# Altium schematic sheet 08_BB_Processor.SchDoc(08_BB_Processor)
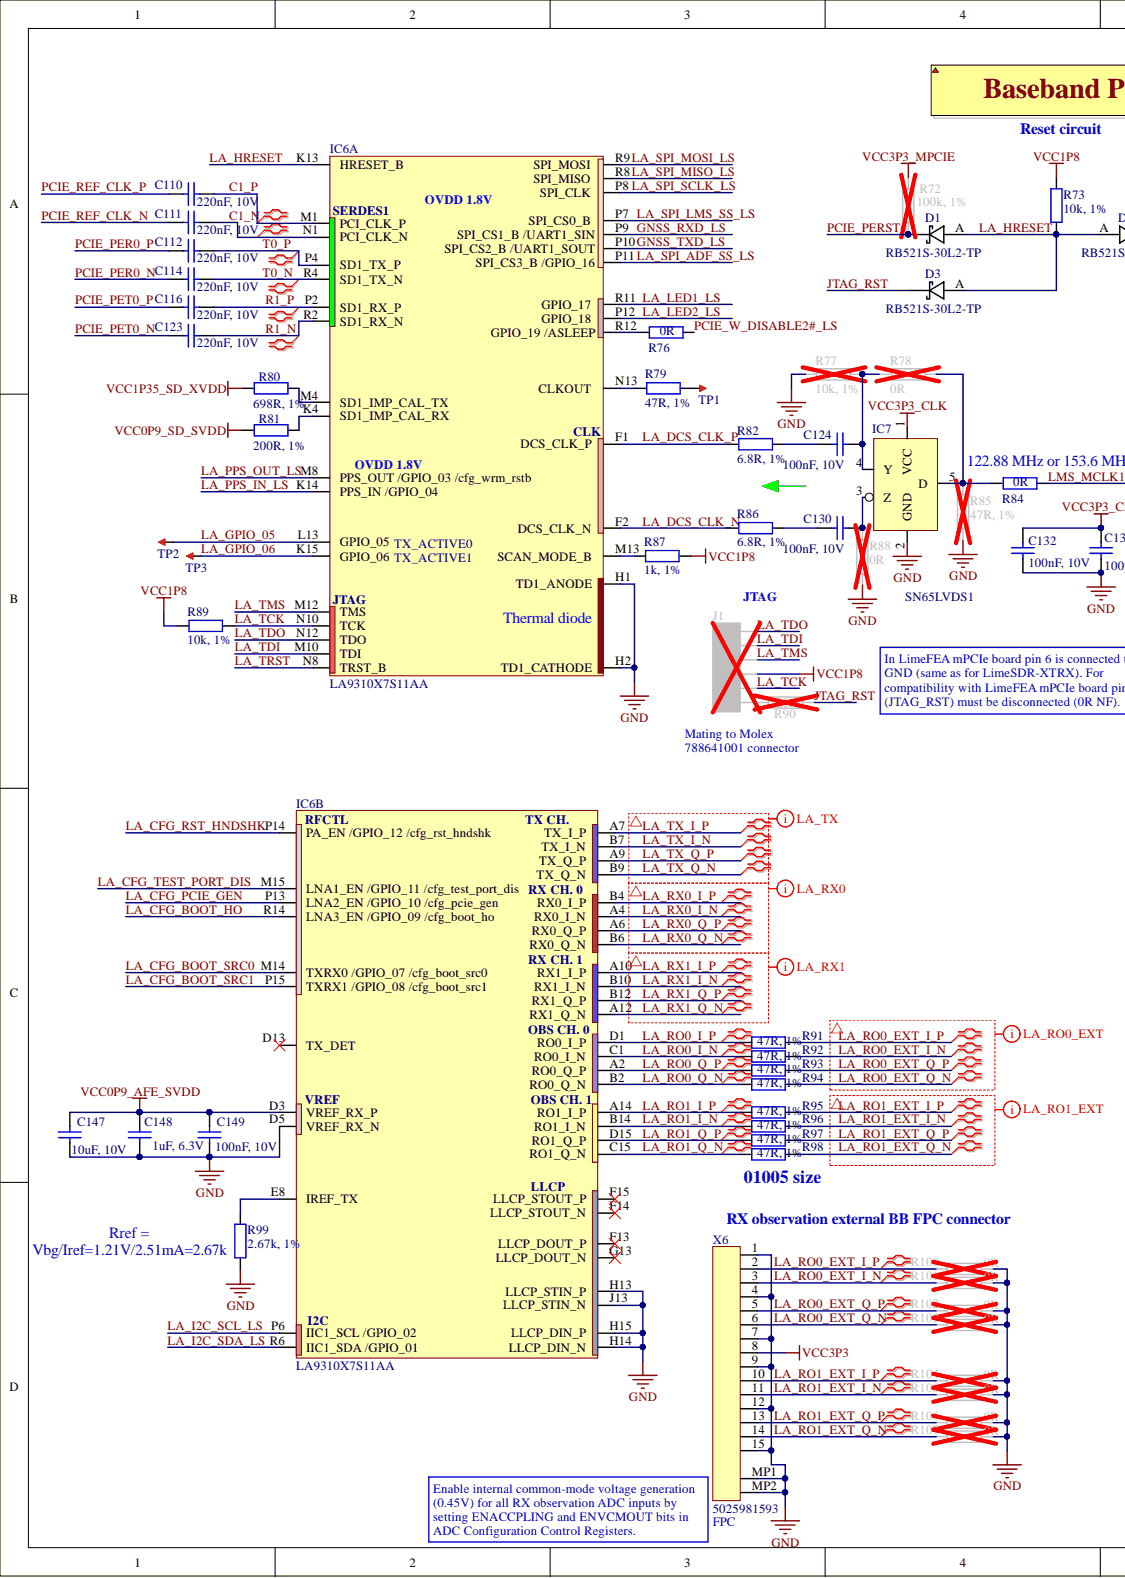
[diagram p0: 08_BB_Processor.SchDoc, left]
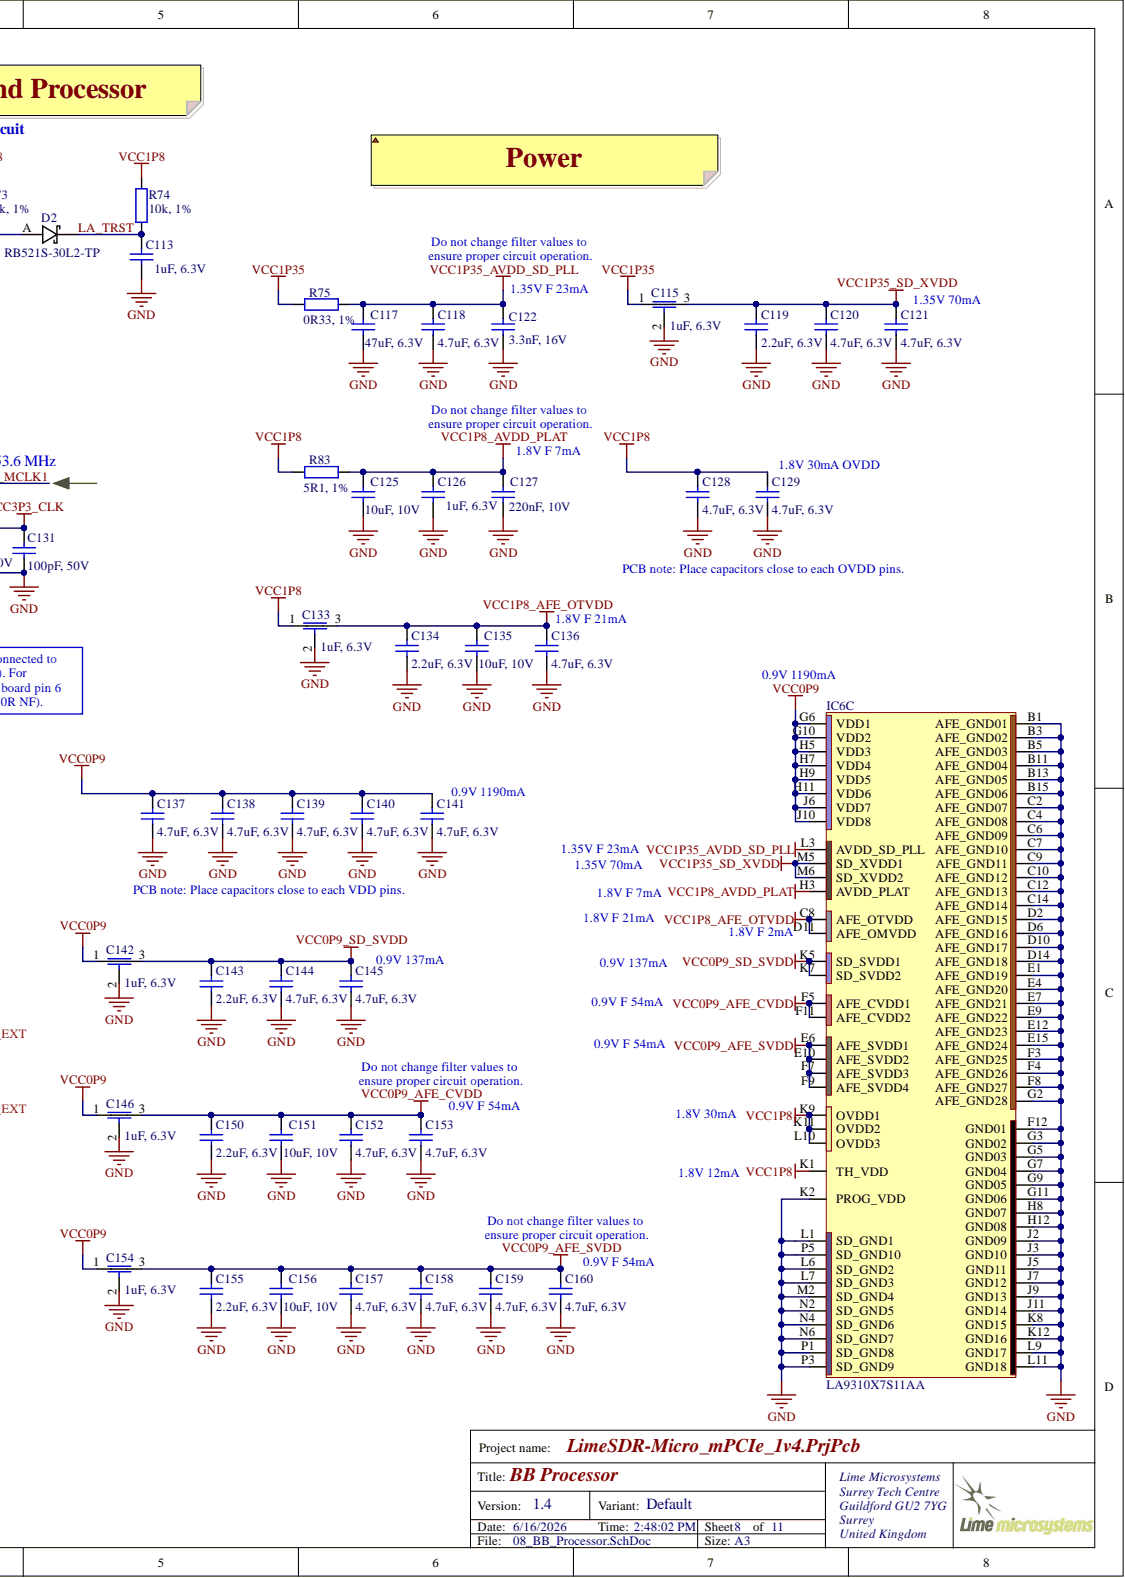
[diagram p1: 08_BB_Processor.SchDoc, right]

## Parts
C110  220nF, 10V  Voltage Rating (DC): 10V  [CAP0201]
C111  220nF, 10V  Voltage Rating (DC): 10V  [CAP0201]
C112  220nF, 10V  Voltage Rating (DC): 10V  [CAP0201]
C113  1uF, 6.3V  Voltage - Rated: 6.3V  [CAP0201]
C114  220nF, 10V  Voltage Rating (DC): 10V  [CAP0201]
C115  1uF, 6.3V  [CAP0603_FEEDTHROUGH]
C116  220nF, 10V  Voltage Rating (DC): 10V  [CAP0201]
C117  47uF, 6.3V  [CAP0603]
C118  4.7uF, 6.3V  Voltage Rating (DC): 6.3V  [CAP0201]
C119  2.2uF, 6.3V  [CAP0201]
C120  4.7uF, 6.3V  Voltage Rating (DC): 6.3V  [CAP0201]
C121  4.7uF, 6.3V  Voltage Rating (DC): 6.3V  [CAP0201]
C122  3.3nF, 16V  [CAP0201]
C123  220nF, 10V  Voltage Rating (DC): 10V  [CAP0201]
C124  100nF, 10V  Voltage Rating (DC): 10V  [CAP0201]
C125  10uF, 10V  [CAP0402]
C126  1uF, 6.3V  [CAP0201]
C127  220nF, 10V  [CAP0201]
C128  4.7uF, 6.3V  [CAP0201]
C129  4.7uF, 6.3V  Voltage Rating (DC): 6.3V  [CAP0201]
C130  100nF, 10V  Voltage Rating (DC): 10V  [CAP0201]
C131  100pF, 50V  Voltage Rating (DC): 50V  [CAP0201]
C132  100nF, 10V  [CAP0201]
C133  1uF, 6.3V  [CAP0603_FEEDTHROUGH]
C134  2.2uF, 6.3V  [CAP0201]
C135  10uF, 10V  [CAP0402]
C136  4.7uF, 6.3V  Voltage Rating (DC): 6.3V  [CAP0201]
C137  4.7uF, 6.3V  [CAP0201]
C138  4.7uF, 6.3V  Voltage Rating (DC): 6.3V  [CAP0201]
C139  4.7uF, 6.3V  Voltage Rating (DC): 6.3V  [CAP0201]
C140  4.7uF, 6.3V  Voltage Rating (DC): 6.3V  [CAP0201]
C141  4.7uF, 6.3V  Voltage Rating (DC): 6.3V  [CAP0201]
C142  1uF, 6.3V  [CAP0603_FEEDTHROUGH]
C143  2.2uF, 6.3V  [CAP0201]
C144  4.7uF, 6.3V  Voltage Rating (DC): 6.3V  [CAP0201]
C145  4.7uF, 6.3V  Voltage Rating (DC): 6.3V  [CAP0201]
C146  1uF, 6.3V  [CAP0603_FEEDTHROUGH]
C147  10uF, 10V  [CAP0402]
C148  1uF, 6.3V  [CAP0201]
C149  100nF, 10V  [CAP0201]
C150  2.2uF, 6.3V  [CAP0201]
C151  10uF, 10V  [CAP0402]
C152  4.7uF, 6.3V  [CAP0201]
C153  4.7uF, 6.3V  Voltage Rating (DC): 6.3V  [CAP0201]
C154  1uF, 6.3V  [CAP0603_FEEDTHROUGH]
C155  2.2uF, 6.3V  [CAP0201]
C156  10uF, 10V  [CAP0402]
C157  4.7uF, 6.3V  [CAP0201]
C158  4.7uF, 6.3V  Voltage Rating (DC): 6.3V  [CAP0201]
C159  4.7uF, 6.3V  Voltage Rating (DC): 6.3V  [CAP0201]
C160  4.7uF, 6.3V  Voltage Rating (DC): 6.3V  [CAP0201]
D1  RB521S-30L2-TP  [RB521S-30L2-TP_DFN1006]
D2  RB521S-30L2-TP  [RB521S-30L2-TP_DFN1006]
D3  RB521S-30L2-TP  [RB521S-30L2-TP_DFN1006]
IC6  LA9310X7S11AA  [LA9310X7S11AA]  (parts A,B,C)
IC7  SN65LVDS1  [SOT23-5_NO_SILK]
J1  Molex 0788641001  [Molex_0788641001_spring_contacts]  (value not drawn)
R72  100k, 1%  [RES0201]
R73  10k, 1%  Tolerance: 1%  [RES0201]
R74  10k, 1%  Tolerance: 1%  [RES0201]
R75  0R33, 1%  [RES0201]
R76  0R  [RES0201]
R77  10k, 1%  Tolerance: 1%  [RES0201]
R78  0R  [RES0201]
R79  47R, 1%  Tolerance: 1%  [RES0201]
R80  698R, 1%  Tolerance: 1%  [RES0201]
R81  200R, 1%  Tolerance: 1%  [RES0201]
R82  6.8R, 1%  Tolerance: 1%  [RES0201]
R83  5R1, 1%  [RES0201]
R84  0R  [RES0201]
R85  47R, 1%  [RES0201]
R86  6.8R, 1%  Tolerance: 1%  [RES0201]
R87  1k, 1%  Tolerance: 1%  [RES0201]
R88  0R  [RES0201]
R89  10k, 1%  Tolerance: 1%  [RES0201]
R90  0R  [RES0201]
R91  47R, 1%  Tolerance: 1%  [RES01005]
R92  47R, 1%  Tolerance: 1%  [RES01005]
R93  47R, 1%  Tolerance: 1%  [RES01005]
R94  47R, 1%  Tolerance: 1%  [RES01005]
R95  47R, 1%  Tolerance: 1%  [RES01005]
R96  47R, 1%  Tolerance: 1%  [RES01005]
R97  47R, 1%  Tolerance: 1%  [RES01005]
R98  47R, 1%  Tolerance: 1%  [RES01005]
R99  2.67k, 1%  [RES0201]
R100  0R  [RES01005]
R101  0R  [RES01005]
R102  0R  [RES01005]
R103  0R  [RES01005]
R104  0R  [RES01005]
R105  0R  [RES01005]
R106  0R  [RES01005]
R107  0R  [RES01005]
TP1  TESTPOINT_PAD  [TP_PAD_1MM]  (value not drawn)  (no BOM)
TP2  TESTPOINT_PAD  [TP_PAD_1MM]  (value not drawn)  (no BOM)
TP3  TESTPOINT_PAD  [TP_PAD_1MM]  (value not drawn)  (no BOM)
X6  5025981593  [5025981593_FPC_connector]

## Nets
GND: C113.2 C115.2 C117.1 C118.1 C119.1 C120.1 C121.1 C122.2 C125.1 C126.2 C127.2 C128.1 C129.1 C131.1 C132.2 C133.2 C134.1 C135.1 C136.1 C137.1 C138.1 C139.1 C140.1 C141.1 C142.2 C143.1 C144.1 C145.1 C146.2 C147.1 C148.2 C149.2 C150.1 C151.1 C152.1 C153.1 C154.2 C155.1 C156.1 C157.1 C158.1 C159.1 C160.1 IC6.B1(AFE_GND01) IC6.B3(AFE_GND02) IC6.B5(AFE_GND03) IC6.B11(AFE_GND04) IC6.B13(AFE_GND05) IC6.B15(AFE_GND06) IC6.C2(AFE_GND07) IC6.C4(AFE_GND08) IC6.C6(AFE_GND09) IC6.C7(AFE_GND10) IC6.C9(AFE_GND11) IC6.C10(AFE_GND12) IC6.C12(AFE_GND13) IC6.C14(AFE_GND14) IC6.D2(AFE_GND15) IC6.D5(VREF_RX_N) IC6.D6(AFE_GND16) IC6.D10(AFE_GND17) IC6.D14(AFE_GND18) IC6.E1(AFE_GND19) IC6.E4(AFE_GND20) IC6.E7(AFE_GND21) IC6.E9(AFE_GND22) IC6.E12(AFE_GND23) IC6.E15(AFE_GND24) IC6.F3(AFE_GND25) IC6.F4(AFE_GND26) IC6.F8(AFE_GND27) IC6.F12(GND01) IC6.G2(AFE_GND28) IC6.G3(GND02) IC6.G5(GND03) IC6.G7(GND04) IC6.G9(GND05) IC6.G11(GND06) IC6.H1(TD1_ANODE) IC6.H2(TD1_CATHODE) IC6.H8(GND07) IC6.H12(GND08) IC6.H13(LLCP_STIN_P) IC6.H14(LLCP_DIN_N) IC6.H15(LLCP_DIN_P) IC6.J2(GND09) IC6.J3(GND10) IC6.J5(GND11) IC6.J7(GND12) IC6.J9(GND13) IC6.J11(GND14) IC6.J13(LLCP_STIN_N) IC6.K2(PROG_VDD) IC6.K8(GND15) IC6.K12(GND16) IC6.L1(SD_GND1) IC6.L6(SD_GND2) IC6.L7(SD_GND3) IC6.L9(GND17) IC6.L11(GND18) IC6.M2(SD_GND4) IC6.N2(SD_GND5) IC6.N4(SD_GND6) IC6.N6(SD_GND7) IC6.P1(SD_GND8) IC6.P3(SD_GND9) IC6.P5(SD_GND10) IC7.2(GND) R77.1 R85.2 R88.1 R99.2 R100.2 R101.2 R102.2 R103.2 R104.2 R105.2 R106.2 R107.2 X6.1 X6.4 X6.7 X6.9 X6.12 X6.15 X6.MP1 X6.MP2
VCC0P9: C137.2 C138.2 C139.2 C140.2 C141.2 C142.1 C146.1 C154.1 IC6.G6(VDD1) IC6.G10(VDD2) IC6.H5(VDD3) IC6.H7(VDD4) IC6.H9(VDD5) IC6.H11(VDD6) IC6.J6(VDD7) IC6.J10(VDD8)
VCC0P9_AFE_CVDD: C146.3 C150.2 C151.2 C152.2 C153.2 IC6.F5(AFE_CVDD1) IC6.F11(AFE_CVDD2)
VCC0P9_AFE_SVDD: C147.2 C148.1 C149.1 C154.3 C155.2 C156.2 C157.2 C158.2 C159.2 C160.2 IC6.D3(VREF_RX_P) IC6.E6(AFE_SVDD1) IC6.E10(AFE_SVDD2) IC6.F7(AFE_SVDD3) IC6.F9(AFE_SVDD4)
VCC0P9_SD_SVDD: C142.3 C143.2 C144.2 C145.2 IC6.K5(SD_SVDD1) IC6.K7(SD_SVDD2) R81.2
VCC1P8: C128.2 C129.2 C133.1 IC6.K1(TH_VDD) IC6.K9(OVDD1) IC6.K11(OVDD2) IC6.L10(OVDD3) J1.4 R73.1 R74.1 R83.2 R87.2 R89.1
VCC1P8_AFE_OTVDD: C133.3 C134.2 C135.2 C136.2 IC6.C8(AFE_OTVDD) IC6.D11(AFE_OMVDD)
VCC1P8_AVDD_PLAT: C125.2 C126.1 C127.1 IC6.H3(AVDD_PLAT) R83.1
VCC1P35: C115.1 R75.2
VCC1P35_AVDD_SD_PLL: C117.2 C118.2 C122.1 IC6.L3(AVDD_SD_PLL) R75.1
VCC1P35_SD_XVDD: C115.3 C119.2 C120.2 C121.2 IC6.M5(SD_XVDD1) IC6.M6(SD_XVDD2) R80.2
VCC3P3: X6.8
VCC3P3_CLK: C131.2 C132.1 IC7.1(VCC)
VCC3P3_MPCIE: R72.1
C1_N: C111.2 IC6.N1(PCI_CLK_N)
C1_P: C110.2 IC6.M1(PCI_CLK_P)
GNSS_RXD_LS: IC6.P9(SPI_CS1_B_/UART1_SIN)
GNSS_TXD_LS: IC6.P10(SPI_CS2_B_/UART1_SOUT)
JTAG_RST: D3.C R90.2
LA_CFG_BOOT_HO: IC6.R14
LA_CFG_BOOT_SRC0: IC6.M14
LA_CFG_BOOT_SRC1: IC6.P15
LA_CFG_PCIE_GEN: IC6.P13
LA_CFG_RST_HNDSHK: IC6.P14
LA_CFG_TEST_PORT_DIS: IC6.M15(LNA1_EN_/GPIO_11_/cfg_test_port_dis)
LA_DCS_CLK_N: IC6.F2(DCS_CLK_N) R86.2
LA_DCS_CLK_P: IC6.F1(DCS_CLK_P) R82.2
LA_GPIO_05: IC6.L13(GPIO_05_TX_ACTIVE0) TP2.1
LA_GPIO_06: IC6.K15(GPIO_06_TX_ACTIVE1) TP3.1
LA_HRESET: D1.A D2.A D3.A IC6.K13(HRESET_B) R73.2
LA_I2C_SCL_LS: IC6.P6(IIC1_SCL_/GPIO_02)
LA_I2C_SDA_LS: IC6.R6(IIC1_SDA_/GPIO_01)
LA_LED1_LS: IC6.R11(GPIO_17)
LA_LED2_LS: IC6.P12(GPIO_18)
LA_PPS_IN_LS: IC6.K14(PPS_IN_/GPIO_04)
LA_PPS_OUT_LS: IC6.M8(PPS_OUT_/GPIO_03_/cfg_wrm_rstb)
LA_RO0_EXT_I_N: R92.2 R101.1 X6.3
LA_RO0_EXT_I_P: R91.2 R100.1 X6.2
LA_RO0_EXT_Q_N: R94.2 R103.1 X6.6
LA_RO0_EXT_Q_P: R93.2 R102.1 X6.5
LA_RO0_I_N: IC6.C1(RO0_I_N) R92.1
LA_RO0_I_P: IC6.D1(RO0_I_P) R91.1
LA_RO0_Q_N: IC6.B2(RO0_Q_N) R94.1
LA_RO0_Q_P: IC6.A2(RO0_Q_P) R93.1
LA_RO1_EXT_I_N: R96.2 R105.1 X6.11
LA_RO1_EXT_I_P: R95.2 R104.1 X6.10
LA_RO1_EXT_Q_N: R98.2 R107.1 X6.14
LA_RO1_EXT_Q_P: R97.2 R106.1 X6.13
LA_RO1_I_N: IC6.B14(RO1_I_N) R96.1
LA_RO1_I_P: IC6.A14(RO1_I_P) R95.1
LA_RO1_Q_N: IC6.C15(RO1_Q_N) R98.1
LA_RO1_Q_P: IC6.D15(RO1_Q_P) R97.1
LA_RX0_I_N: IC6.A4(RX0_I_N)
LA_RX0_I_P: IC6.B4(RX0_I_P)
LA_RX0_Q_N: IC6.B6(RX0_Q_N)
LA_RX0_Q_P: IC6.A6(RX0_Q_P)
LA_RX1_I_N: IC6.B10(RX1_I_N)
LA_RX1_I_P: IC6.A10(RX1_I_P)
LA_RX1_Q_N: IC6.A12(RX1_Q_N)
LA_RX1_Q_P: IC6.B12(RX1_Q_P)
LA_SPI_ADF_SS_LS: IC6.P11(SPI_CS3_B_/GPIO_16)
LA_SPI_LMS_SS_LS: IC6.P7(SPI_CS0_B)
LA_SPI_MISO_LS: IC6.R8(SPI_MISO)
LA_SPI_MOSI_LS: IC6.R9(SPI_MOSI)
LA_SPI_SCLK_LS: IC6.P8(SPI_CLK)
LA_TCK: IC6.N10(TCK) J1.5 R89.2
LA_TDI: IC6.M10(TDI) J1.2
LA_TDO: IC6.N12(TDO) J1.1
LA_TMS: IC6.M12(TMS) J1.3
LA_TRST: C113.1 D2.C IC6.N8(TRST_B) R74.2
LA_TX_I_N: IC6.B7(TX_I_N)
LA_TX_I_P: IC6.A7(TX_I_P)
LA_TX_Q_N: IC6.B9(TX_Q_N)
LA_TX_Q_P: IC6.A9(TX_Q_P)
LMS_MCLK1: R84.1
PCIE_PER0_N: C114.1
PCIE_PER0_P: C112.1
PCIE_PERST#: D1.C R72.2
PCIE_PET0_N: C123.1
PCIE_PET0_P: C116.1
PCIE_REF_CLK_N: C111.1
PCIE_REF_CLK_P: C110.1
PCIE_W_DISABLE2#_LS: R76.1
R1_N: C123.2 IC6.R2(SD1_RX_N)
R1_P: C116.2 IC6.P2(SD1_RX_P)
T0_N: C114.2 IC6.R4(SD1_TX_N)
T0_P: C112.2 IC6.P4(SD1_TX_P)
NetC124_1: C124.1 R82.1
NetC124_2: C124.2 IC7.4(Y) R77.2 R78.2
NetC130_1: C130.1 R86.1
NetC130_2: C130.2 IC7.3(Z) R88.2
NetIC6_E8: IC6.E8(IREF_TX) R99.1
NetIC6_K4: IC6.K4(SD1_IMP_CAL_RX) R81.1
NetIC6_M4: IC6.M4(SD1_IMP_CAL_TX) R80.1
NetIC6_M13: IC6.M13(SCAN_MODE_B) R87.1
NetIC6_N13: IC6.N13(CLKOUT) R79.2
NetIC6_R12: IC6.R12(GPIO_19_/ASLEEP) R76.2
NetIC7_5: IC7.5(D) R78.1 R84.2 R85.1
NetJ1_6: J1.6 R90.1
NetR79_1: R79.1 TP1.1
N00324:
N00325:
N00326:
N00327:
N00328:

Not connected: IC6 D13(TX_DET) F13(LLCP_DOUT_P) F14(LLCP_STOUT_N) F15(LLCP_STOUT_P) G13(LLCP_DOUT_N)
